# Altium schematic sheet PwrSwitch.SchDoc
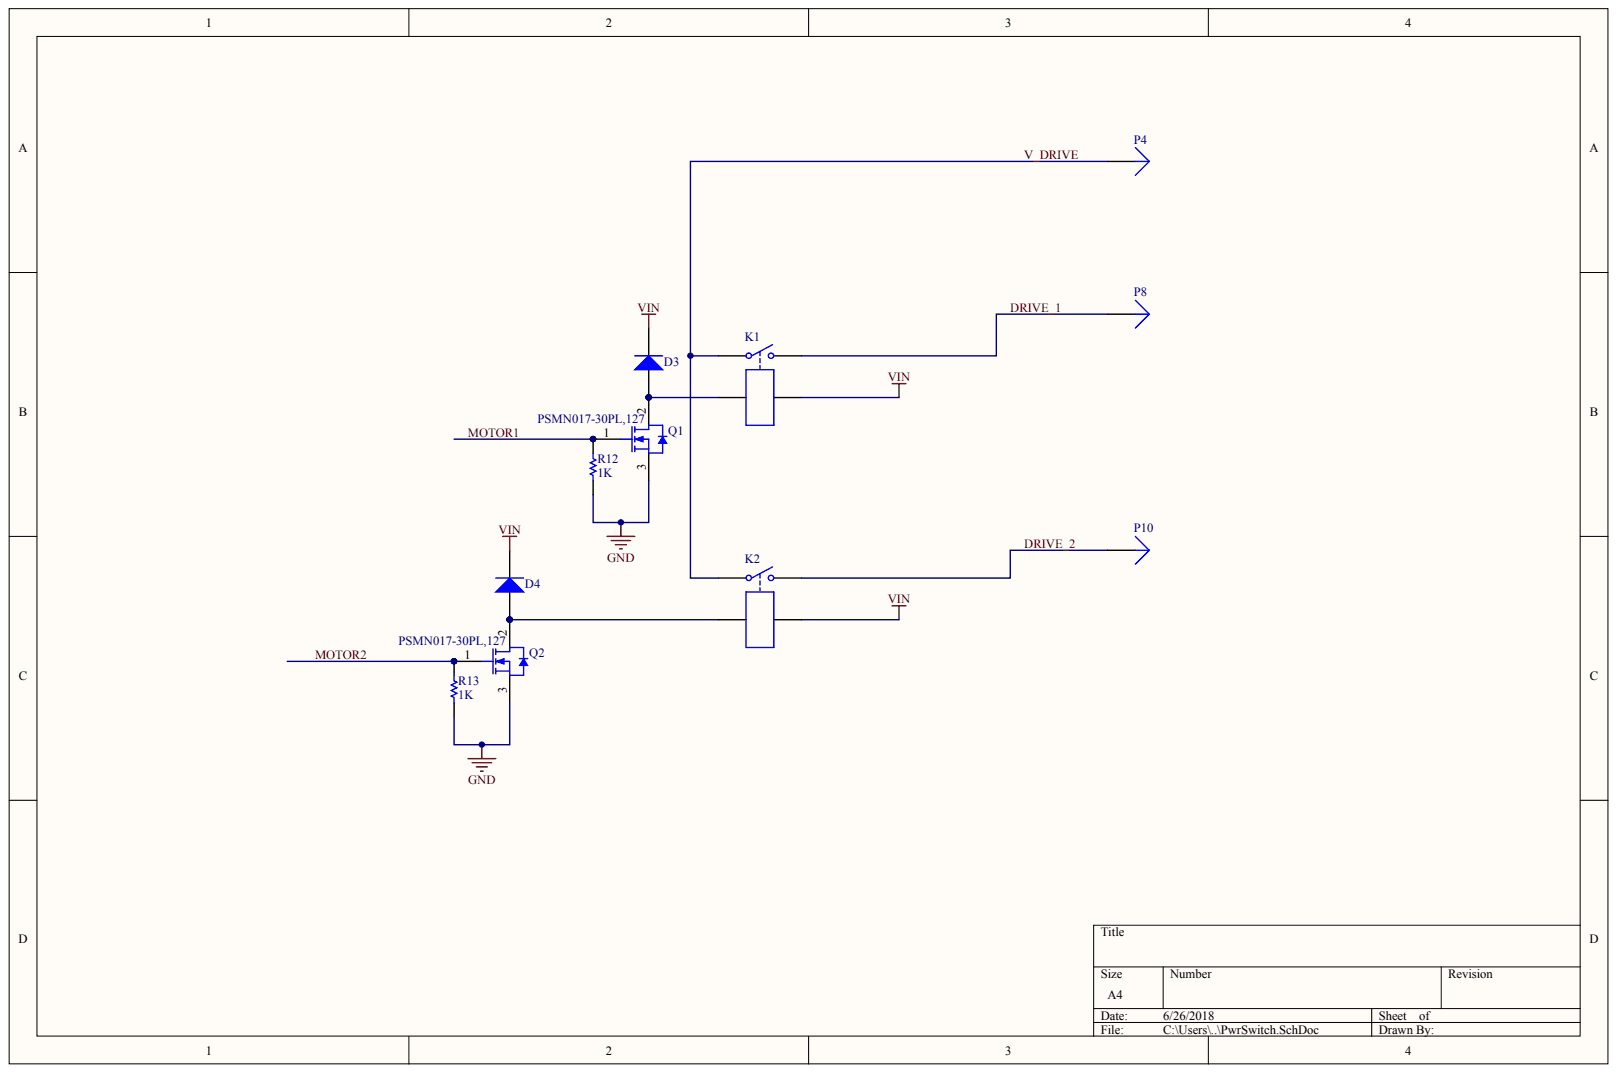
[diagram p0: PwrSwitch.SchDoc]

## Parts
D3  Diode 1N4007  (value not drawn)
D4  Diode 1N4007  (value not drawn)
K1  Relay-SPST  (value not drawn)
K2  Relay-SPST  (value not drawn)
P4  Plug  (value not drawn)
P8  Plug  (value not drawn)
P10  Plug  (value not drawn)
Q1  PSMN017-30PL,127
Q2  PSMN017-30PL,127
R12  value 1K  (hidden comment: Res1)
R13  value 1K  (hidden comment: Res1)

## Nets
GND: Q1.3 Q2.3 R12.1 R13.1
VIN: D3.2 D4.2 K1.4 K2.4
DRIVE_1: K1.2 P8.1
DRIVE_2: K2.2 P10.1
MOTOR1: Q1.1 R12.2
MOTOR2: Q2.1 R13.2
V_DRIVE: K1.1 K2.1 P4.1
NetD3_1: D3.1 K1.3 Q1.2
NetD4_1: D4.1 K2.3 Q2.2

## Library data of the parts
Q1 Q2 = (no symbol name): Manufacturer: NXP Semiconductors; Coss [typ] (pF): 127; VGSth [typ] (V): 1.7; VDS [max] (V): 30; Channel Type: N; Qr [typ] (nC): 6.5; Ciss [typ] (pF): 552; Packing: Tube; ID [max] (A): 32; ComponentLink3URL: http://www.nxp.com/documents/outline_drawing/sot078_po.pdf; PackageDescription: 3-Pin Flange Mount, Vertical Straight Lead, Body 10 x 4.4 mm, Pitch 2.54 mm; PackageVersion: 08-06-13, 06/2008; ComponentLink2URL: http://www.nxp.com/documents/data_sheet/PSMN017-30PL.pdf; PartNumber: PSMN017-30PL,127; ComponentLink2Description: Datasheet; DatasheetVersion: Rev. 2; ComponentLink1URL: http://www.nxp.com/; Code_JEDEC: 3-lead TO-220AB; Mounting Technology: Through Hole; PackageReference: SOT78; Code_JEITA: SC-46; ComponentLink1Description: Manufacturer URL; ComponentLink3Description: Package Specification
D3 D4 K1 K2 P4 P8 P10 R12 R13 = (no symbol name): LatestRevisionDate: 17-Jul-2002; LatestRevisionNote: Re-released for DXP Platform.; Publisher: Altium Limited
  D3 D4: Published: 8-Jun-2000; DatasheetVersion: 1998; PackageVersion: Aug-1999; PackageReference: DO-41; PackageDescription: Diode, Axial; Body 5.2 x 2.7 mm (LxDia. max), Lead Dia. 0.86 mm (max); Code_JEDEC: DO-204-AL; ComponentLink1Description: Manufacturer Link; ComponentLink1URL: http://www.fairchildsemi.com/; ComponentLink2Description: Datasheet; ComponentLink2URL: http://www.fairchildsemi.com/ds/1N%2F1N4001.pdf; Digikey PN: 497-2447-1-ND
  K1 K2: Published: 24-Mar-1999; PackageReference: MODULE4; Digikey PN: 255-2099-5-ND
  R12 R13: Published: 8-Jun-2000; PackageReference: AXIAL-0.3; PackageDescription: Axial Device, Thru-Hole; 2 Leads; 0.3 in Pin Spacing
